# Altium schematic sheet SENSOR (TEMP-FLUJO).SchDoc("SENSOR (TEMP-FLUJO)")
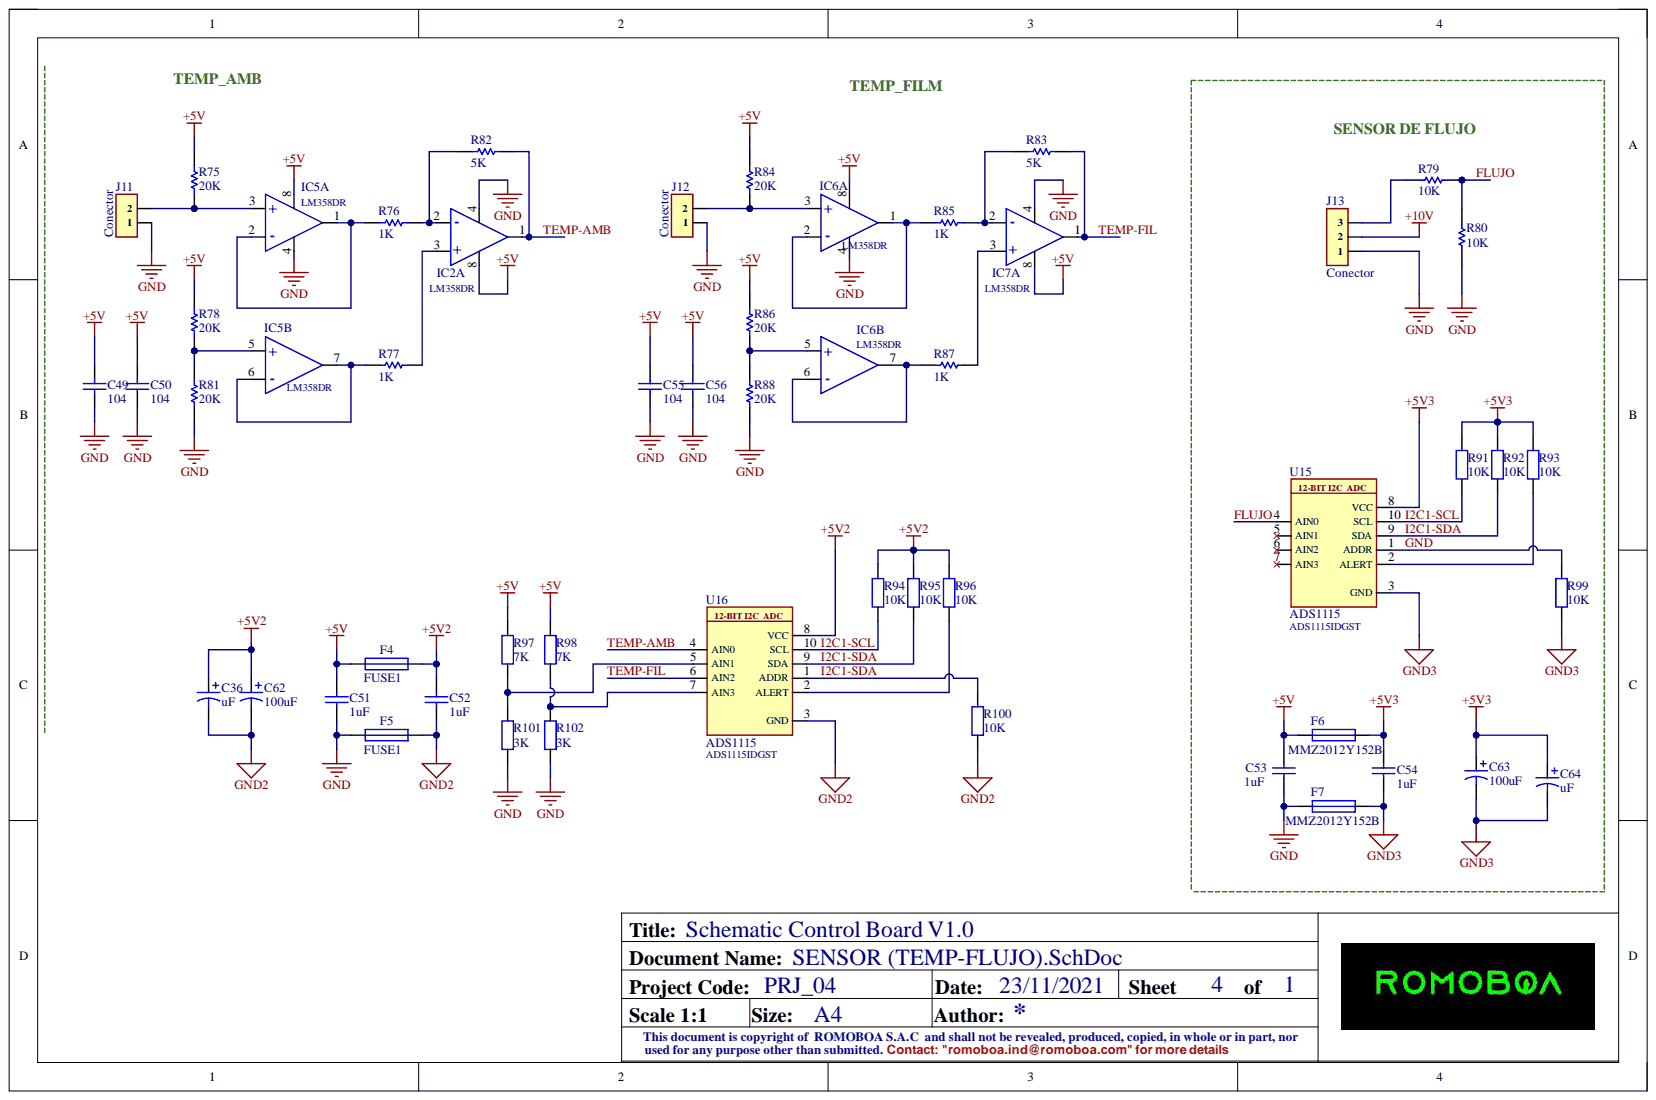
[diagram p0: SENSOR ]

## Parts
C36  uF  [CAP: TANTAL-B]
C49  104  [CAP0805]
C50  104  [CAP0805]
C51  1uF  [CAP0805]
C52  1uF  [CAP0805]
C53  1uF  [CAP0805]
C54  1uF  [CAP0805]
C55  104  [CAP0805]
C56  104  [CAP0805]
C62  100uF  [CAPAE6.6x6.6X55mm]
C63  100uF  [CAPAE6.6x6.6X55mm]
C64  uF  [CAP: TANTAL-B]
F4  FUSE1  [FUSE: 0805]
F5  FUSE1  [FUSE: 0805]
F6  MMZ2012Y152B  [FUSE: 0805]
F7  MMZ2012Y152B  [FUSE: 0805]
IC2  LM358  Manufacturer Part Number: LM358DR  [MAX485 :SOIC-8]  (part A)
IC5  LM358  Manufacturer Part Number: LM358DR  [MAX485 :SOIC-8]  (parts A,B)
IC6  LM358  Manufacturer Part Number: LM358DR  [MAX485 :SOIC-8]  (parts A,B)
IC7  LM358  Manufacturer Part Number: LM358DR  [MAX485 :SOIC-8]  (part A)
J11  Conector  [MOLEX KK 0022272021]
J12  Conector  [MOLEX KK 0022272021]
J13  Conector  [MOLEX KK 0022272031]
R75  20K  [PRESICION CSR0805]
R76  1K  [PRESICION CSR0805]
R77  1K  [PRESICION CSR0805]
R78  20K  [PRESICION CSR0805]
R79  10K  [PRESICION CSR0805]
R80  10K  [PRESICION CSR0805]
R81  20K  [PRESICION CSR0805]
R82  5K  [PRESICION CSR0805]
R83  5K  [PRESICION CSR0805]
R84  20K  [PRESICION CSR0805]
R85  1K  [PRESICION CSR0805]
R86  20K  [PRESICION CSR0805]
R87  1K  [PRESICION CSR0805]
R88  20K  [PRESICION CSR0805]
R91  10K  [RES: 0805R]
R92  10K  [RES: 0805R]
R93  10K  [RES: 0805R]
R94  10K  [RES: 0805R]
R95  10K  [RES: 0805R]
R96  10K  [RES: 0805R]
R97  7K  [RES: 0805R]
R98  7K  [RES: 0805R]
R99  10K  [RES: 0805R]
R100  10K  [RES: 0805R]
R101  3K  [RES: 0805R]
R102  3K  [RES: 0805R]
U15  ADS1115  Interface: I2C  Manufacturer Part Number: ADS1115IDGST  [CH340E: 10-MSOP]
U16  ADS1115  Interface: I2C  Manufacturer Part Number: ADS1115IDGST  [CH340E: 10-MSOP]

## Nets
+5V: C49.2 C50.2 C51.2 C53.2 C55.2 C56.2 F4.1 F6.1 IC2.8 IC5.8 IC6.8 IC7.8 R75.2 R78.2 R84.2 R86.2 R97.2 R98.2
+5V2: C36.1 C52.2 C62.1 F4.2 R94.1 R95.1 R96.1 U16.8(VCC)
+5V3: C54.2 C63.1 C64.1 F6.2 R91.1 R92.1 R93.1 U15.8(VCC)
+10V: J13.2
GND2: C36.2 C52.1 C62.2 F5.2 R100.2 U16.3(GND)
GND3: C54.1 C63.2 C64.2 F7.2 R99.2 U15.3(GND)
FLUJO: R79.2 R80.2 U15.4(AIN0)
GND: C49.1 C50.1 C51.1 C53.1 C55.1 C56.1 F5.1 F7.1 IC2.4 IC5.4 IC6.4 IC7.4 J11.1 J12.1 J13.1 R80.1 R81.1 R88.1 R99.1 R101.1 R102.1 U15.1(ADDR)
I2C1-SCL: R91.2 R94.2 U15.10(SCL) U16.10(SCL)
I2C1-SDA: R92.2 R95.2 R100.1 U15.9(SDA) U16.1(ADDR) U16.9(SDA)
TEMP-AMB: IC2.1 R82.1 U16.4(AIN0)
TEMP-FIL: IC7.1 R83.1 U16.6(AIN2)
NetIC2_2: IC2.2(-) R76.1 R82.2
NetIC2_3: IC2.3(+) R77.1
NetIC5_1: IC5.1 IC5.2(-) R76.2
NetIC5_3: IC5.3(+) J11.2 R75.1
NetIC5_5: IC5.5(+) R78.1 R81.2
NetIC5_6: IC5.6(-) IC5.7 R77.2
NetIC6_1: IC6.1 IC6.2(-) R85.2
NetIC6_3: IC6.3(+) J12.2 R84.1
NetIC6_5: IC6.5(+) R86.1 R88.2
NetIC6_6: IC6.6(-) IC6.7 R87.2
NetIC7_2: IC7.2(-) R83.2 R85.1
NetIC7_3: IC7.3(+) R87.1
NetJ13_3: J13.3 R79.1
NetR93_2: R93.2 U15.2(ALERT)
NetR96_2: R96.2 U16.2(ALERT)
NetR97_1: R97.1 R101.2 U16.5(AIN1)
NetR98_1: R98.1 R102.2 U16.7(AIN3)

Not connected: U15 5(AIN1) 6(AIN2) 7(AIN3)

## Library data of the parts
C36 C62-C64 = CAP_SMD_P: CAPACITOR SMD POLARIZADO; Component Kind: Standard; Library Name: CAPACITORES.IntLib
C49-C56 = CAP_SMD_NP: CAPACITOR SMD NO POLARIZADO; Component Kind: Standard; Library Name: CAPACITORES.IntLib
F4-F7 = FUSE1: FUSE; Component Kind: Standard; Library Name: FUSE-VARISTOR.IntLib
IC2 IC5-IC7 = LM358: 2 Amplifier, 700 kHz, 0.3 V/µs, 3V to 32V, ± 1.5V to ± 16V, SOIC, 8 Pins; Manufacturer: Texas Instruments; Bandwidth: 700kHz; Common Mode Rejection Ratio: 65dB; Dual Supply Voltage: 9V; Input Bias Current: 250nA; Input Offset Voltage (Vos): 7mV; Nominal Supply Current: 1.2mA; Number of Amplifiers: 2; Operating Supply Current: 1mA; Output Current: 30mA; Voltage Gain: 100dB; Case/Package: SOIC; Category: Amplifiers - Op Amps, Buffer, Instrumentation; Component Kind: Standard; ComponentLink1Description: https://datasheet.ciiva.com/1795/lm358-1795488.pdf?src-supplier=Digi-Key; ComponentLink1URL: https://datasheet.ciiva.com/1795/lm358-1795488.pdf?src-supplier=Digi-Key; ComponentLink2Description: https://datasheet.ciiva.com/27056/2016330-27056228.pdf?src-supplier=Jameco; ComponentLink2URL: https://datasheet.ciiva.com/27056/2016330-27056228.pdf?src-supplier=Jameco; ComponentLink3Description: https://datasheet.ciiva.com/26847/lm358dr-26847142.pdf?src-supplier=IIIC; ComponentLink3URL: https://datasheet.ciiva.com/26847/lm358dr-26847142.pdf?src-supplier=IIIC; ComponentLink4Description: https://datasheet.ciiva.com/2973/lm358a-2973386.pdf?src-supplier=Avnet; ComponentLink4URL: https://datasheet.ciiva.com/2973/lm358a-2973386.pdf?src-supplier=Avnet; ComponentLink5Description: https://datasheet.ciiva.com/46385/1874566-46385851.pdf?src-supplier=Element14; ComponentLink5URL: https://datasheet.ciiva.com/46385/1874566-46385851.pdf?src-supplier=Element14; ComponentLink6Description: https://datasheet.ciiva.com/46390/1874566-46390414.pdf?src-supplier=Element14; ComponentLink6URL: https://datasheet.ciiva.com/46390/1874566-46390414.pdf?src-supplier=Element14; ComponentLink7Description: https://datasheet.ciiva.com/1795/lm358-1795487.pdf?src-supplier=Digi-Key; ComponentLink7URL: https://datasheet.ciiva.com/1795/lm358-1795487.pdf?src-supplier=Digi-Key; ComponentLink8Description: https://datasheet.ciiva.com/1795/lm358-1795489.pdf?src-supplier=Digi-Key; ComponentLink8URL: https://datasheet.ciiva.com/1795/lm358-1795489.pdf?src-supplier=Digi-Key; ComponentLink9Description: https://datasheet.ciiva.com/7709/1874566-7709823.pdf?src-supplier=Element14; ComponentLink9URL: https://datasheet.ciiva.com/7709/1874566-7709823.pdf?src-supplier=Element14; Contact Plating: Gold; Gain Bandwidth Product: 700kHz; Height: 1.58mm; Lead Free: Lead Free; Length: 4.9mm; Max Dual Supply Voltage: 16V; Max Operating Temperature: 70°C; Max Supply Voltage: 32V; Min Dual Supply Voltage: 1.5V; Min Operating Temperature: 0°C; Min Supply Voltage: 3V; Mount: Surface Mount; Number of Channels: 2; Number of Circuits: 2; Number of Elements: 2; Number of Pins: 8; Operating Supply Voltage: 16V; Output Current per Channel: 30mA; Package Quantity: 2500; Packaging: Tape and Reel; Power Supply Rejection Ratio (PSRR): 65dB; Pricing 1: 5=0.18570, 50=0.14470, 150=0.13720, 500=0.12970, 2500=0.12630, 5000=0.12480, 5=0.18240, 50=0.14220, 150=0.13480, 500=0.12740, 2500=0.12410, 5000=0.12260, 5=0.92450, 50=0.72060, 150=0.68320, 500=0.64580, 2500=0.62900, 5000=0.62130, 5=0.88370, 50=0.68890, 150=0.65310, 500=0.61730, 2500=0.60130, 5000=0.59390, 5=0.11880, 50=0.09260, 150=0.08780, 500=0.08300, 2500=0.08080, 5000=0.07980, 5=0.10420, 50=0.08120, 150=0.07700, 500=0.07280, 2500=0.07090, 5000=0.07000, 5=1.11090, 50=0.86590, 150=0.82100, 500=0.77600, 2500=0.75590, 5000=0.74660, 5=15.06480, 50=11.74280, 150=11.13310, 500=10.52330, 2500=10.25000, 5000=10.12380, 5=0.58200, 50=0.45370, 150=0.43010, 500=0.40660, 2500=0.39600, 5000=0.39110, 5=10.66160, 50=8.31050, 150=7.87900, 500=7.44750, 2500=7.25400, 5000=7.16480, 5=1.20130, 50=0.93640, 150=0.88780, 500=0.83910, 2500=0.81730, 5000=0.80730, 5=0.19080, 50=0.14880, 150=0.14100, 500=0.13330, 2500=0.12990, 5000=0.12830, 5=0.14330, 50=0.11170, 150=0.10590, 500=0.10010, 2500=0.09750, 5000=0.09630 (AUD); Radiation Hardening: No; REACH SVHC: No SVHC; RoHS: Yes; Slew Rate: 0.3V/µs; Stock 1: 220345; Supplier 1: LCSC; Supplier Part Number 1: C5423; Unity Gain Bandwidth Product: 700kHz; Width: 3.91mm; Library Name: IC-COMUNICACION.IntLib
J11 J12 = HEADER 1X2: HEADER  THT 2.54MM; Component Kind: Standard; Library Name: CONECTORES-HEADER.IntLib
J13 = HEADER 1X3: HEADER THT 2.54MM; Component Kind: Standard; Library Name: CONECTORES-HEADER.IntLib
R75-R88 = Res1-SMD: Component Kind: Standard; Library Name: RESISTOR-POT..IntLib
R91-R102 = Res2-SMD: Component Kind: Standard; Library Name: RESISTOR-POT..IntLib
U15 U16 = ADS1115: ANALOG TO DIGITAL CONVERTER ADC, 16 BIT, 860SPS, MSOP-10; Manufacturer: Texas Instruments; Conversion Rate: 860S/s; Integral Nonlinearity (INL): 1LSB; Max Power Dissipation: 500uW; Max Supply Voltage: 5.5V; Min Supply Voltage: 2V; Nominal Supply Current: 150uA; Number of A/D Converters: 1; Number of Bits: 16; Number of Channels: 4; Operating Supply Voltage: 3.3V; Polarity: Bipolar; Power Dissipation: 900uW; Resolution: 16b; Supply Type: Single; Case/Package: VSSOP; Category: Analog to Digital Converters (ADCs); Component Kind: Standard; ComponentLink1Description: https://datasheet.ciiva.com/46384/1789034-46384549.pdf?src-supplier=Element14; ComponentLink1URL: https://datasheet.ciiva.com/46384/1789034-46384549.pdf?src-supplier=Element14; ComponentLink2Description: https://datasheet.ciiva.com/15148/getdatasheetpartid-202210-15148135.pdf?src-supplier=Verical; ComponentLink2URL: https://datasheet.ciiva.com/15148/getdatasheetpartid-202210-15148135.pdf?src-supplier=Verical; ComponentLink3Description: https://datasheet.ciiva.com/1261/ads1113-1261331.pdf?src-supplier=Digi-Key; ComponentLink3URL: https://datasheet.ciiva.com/1261/ads1113-1261331.pdf?src-supplier=Digi-Key; ComponentLink4Description: https://datasheet.ciiva.com/1261/ads1113-1261332.pdf?src-supplier=Digi-Key; ComponentLink4URL: https://datasheet.ciiva.com/1261/ads1113-1261332.pdf?src-supplier=Digi-Key; ComponentLink5Description: https://datasheet.ciiva.com/1261/ads1113-1261333.pdf?src-supplier=Digi-Key; ComponentLink5URL: https://datasheet.ciiva.com/1261/ads1113-1261333.pdf?src-supplier=Digi-Key; ComponentLink6Description: https://datasheet.ciiva.com/25993/sbas444b-25993209.pdf?src-supplier=Avnet+Europe; ComponentLink6URL: https://datasheet.ciiva.com/25993/sbas444b-25993209.pdf?src-supplier=Avnet+Europe; ComponentLink7Description: https://datasheet.ciiva.com/1704/1789034-1704282.pdf?src-supplier=Element14; ComponentLink7URL: https://datasheet.ciiva.com/1704/1789034-1704282.pdf?src-supplier=Element14; ComponentLink8Description: https://datasheet.ciiva.com/3039/sbas444b-3039517.pdf?src-supplier=Avnet; ComponentLink8URL: https://datasheet.ciiva.com/3039/sbas444b-3039517.pdf?src-supplier=Avnet; ComponentLink9Description: https://datasheet.ciiva.com/3037/sbas444b-3037903.pdf?src-supplier=Avnet; ComponentLink9URL: https://datasheet.ciiva.com/3037/sbas444b-3037903.pdf?src-supplier=Avnet; ComponentLink10Description: https://datasheet.ciiva.com/22696/1789034-22696415.pdf?src-supplier=Element14; ComponentLink10URL: https://datasheet.ciiva.com/22696/1789034-22696415.pdf?src-supplier=Element14; Contact Plating: Gold; Height: 1.02mm; Lead Free: Lead Free; Length: 3mm; Max Operating Temperature: 125°C; Max Supply Voltage (DC): 5.5V; Min Operating Temperature: -40°C; Min Supply Voltage (DC): 2V; Number of Converters: 1; Number of Elements: 1; Number of Inputs: 4; Number of Pins: 10; Package Quantity: 250; Packaging: Tape and Reel; Pricing 1: 1=6.10000, 10=5.48000, 50=5.18000, 100=4.26000, 1000=3.06000, 10000=2.95000 (USD); Radiation Hardening: No; REACH SVHC: No SVHC; RoHS: Yes; RoHS Compliant: Yes; Sampling Rate: 860S/s; Stock 1: 4127; Supplier 1: Mouser; Supplier Part Number 1: 595-ADS1115IDGST; Termination: SMD/SMT; Width: 3mm; Library Name: IC-COMUNICACION.IntLib
